# Altium schematic sheet 02_Ext_UART.SchDoc
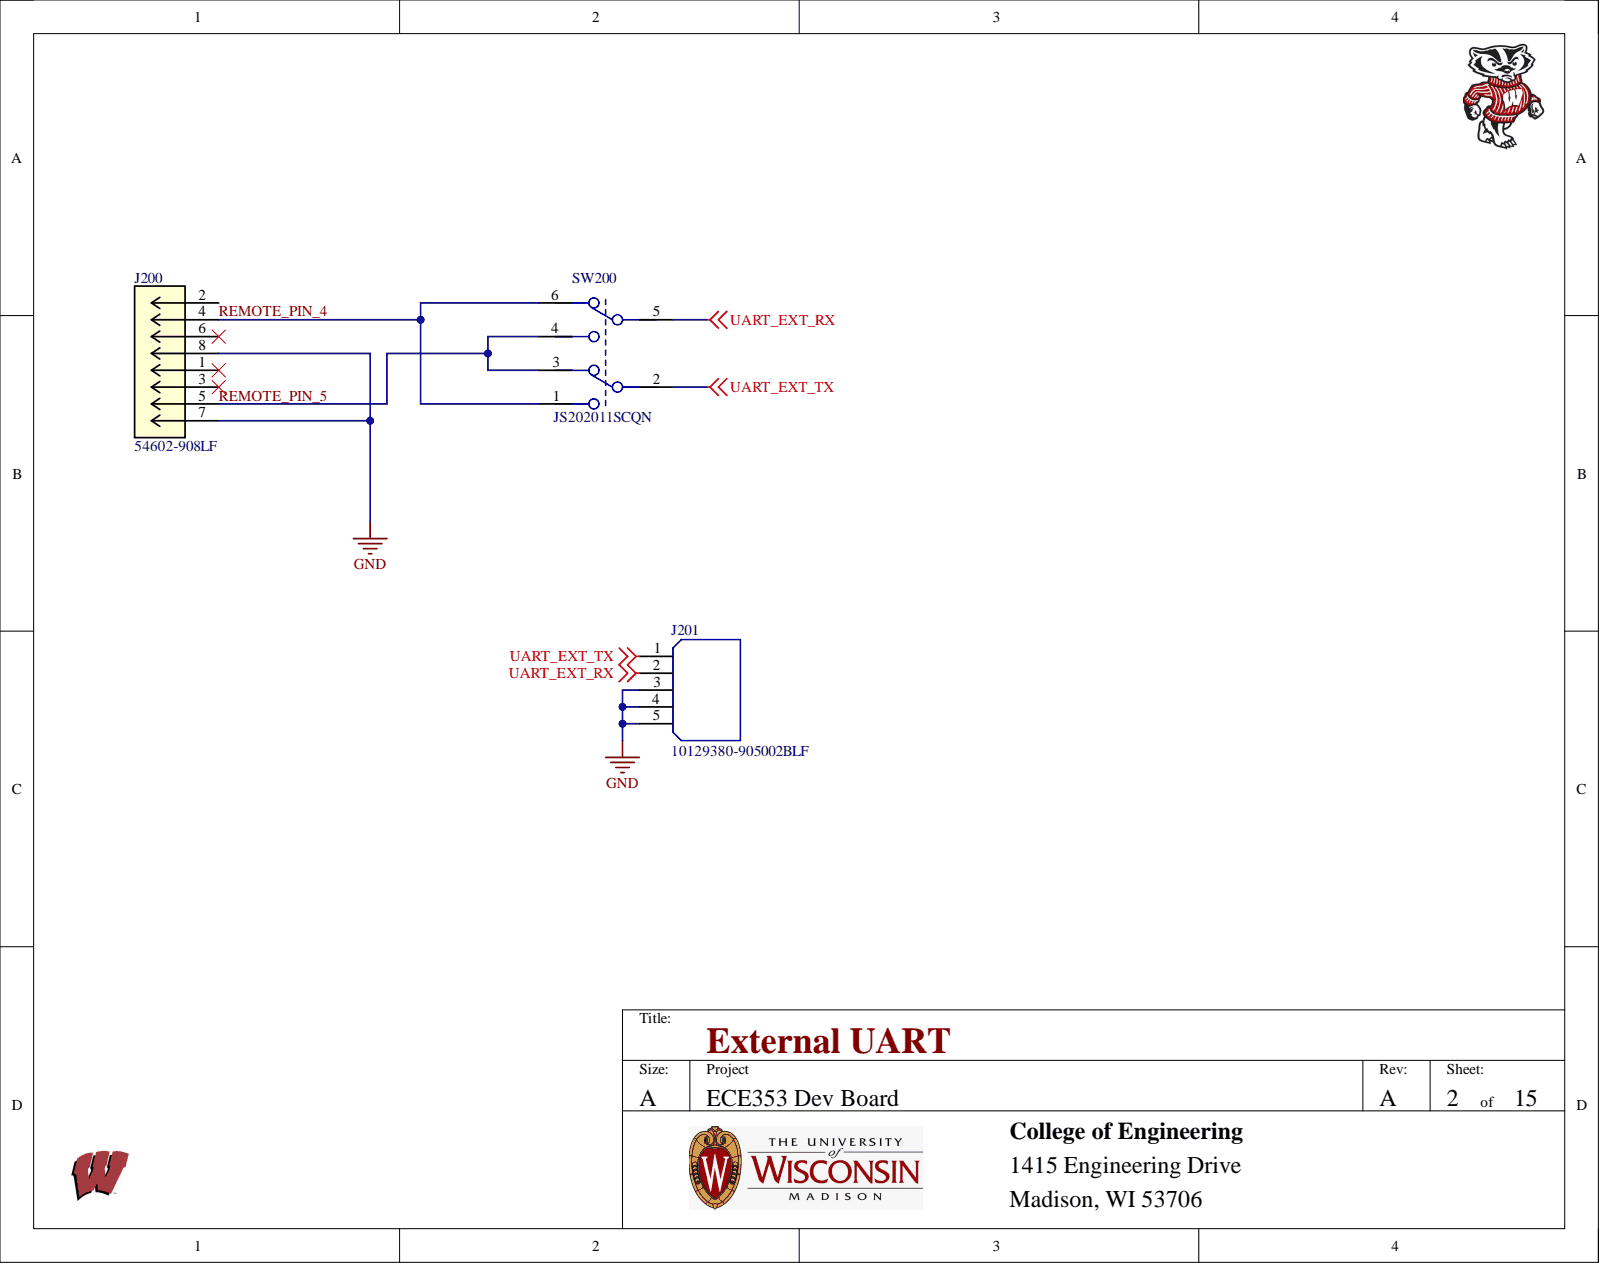
[diagram p0: 02_Ext_UART.SchDoc]

## Parts
J200  54602-908LF  [CONN8_2-908LF_AMP]
J201  10129380-905002BLF  [AMPHENOL_10129380-905002BLF]
SW200  JS202011SCQN  [FP-JS202011SCQN-MFG]

## Nets
GND: J200.7 J200.8 J201.3 J201.4 J201.5
UART_EXT_RX: J201.2 SW200.5
UART_EXT_TX: J201.1 SW200.2
REMOTE_PIN_4: J200.4 SW200.1 SW200.6
REMOTE_PIN_5: J200.5 SW200.3 SW200.4

Not connected: J200 1 2 3 6

## Library data of the parts
J200 = 54602-908LF: Conn Modular Jack F 8 POS 2.54mm Solder RA Thru-Hole 8 Terminal 1 Port Box Cat 3; Manufacturer 1: Amphenol ICC / FCI; Mfr_Name: Amphenol ICC; Category: Modular / Ethernet Connectors; Component Kind: Standard; ComponentLink1Description: https://datasheet.octopart.com/54602-908LF-Areva-datasheet-11761423.pdf?src-supplier=Farnell; ComponentLink1URL: https://datasheet.octopart.com/54602-908LF-Areva-datasheet-11761423.pdf?src-supplier=Farnell; ComponentLink2Description: https://datasheet.octopart.com/54602-908LF-Amphenol-Communications-Solutions-datasheet-94059534.pdf?src-supplier=Element14; ComponentLink2URL: https://datasheet.octopart.com/54602-908LF-Amphenol-Communications-Solutions-datasheet-94059534.pdf?src-supplier=Element14; Library Name: ece353-2022.SchLib; Manufacturer Part Number 1: 54602-908LF; Pricing 1: 1=0.78, 10=0.682, 25=0.6236, 50=0.5868, 100=0.5624, 250=0.51348, 500=0.48904, 1000=0.40346, 2500=0.36678 (USD); RefDes: RefDes; RoHS: No; Stock 1: 193161; Supplier 1: Digi-Key; Supplier Part Number 1: 609-1046-ND; Type: Type
J201 = 10129380-905002BLF: Conn Unshrouded Header HDR 5 POS 2.54mm Solder ST SMD Poly Bag; Manufacturer 1: ICC; MF: AMPHENOL ICC / FCI; Availability: In Stock; Check_prices: https://www.snapeda.com/parts/10129380-905002BLF/Amphenol/view-part/?ref=eda; Component Kind: Standard; Library Name: ece353-2022.SchLib; Manufacturer Part Number 1: 10129380-905002BLF; MP: 10129380-905002BLF; Package: None; Price: None; Purchase-URL: https://pricing.snapeda.com/search/part/10129380-905002BLF/?ref=eda; RoHS: No; SnapEDA_Link: https://www.snapeda.com/parts/10129380-905002BLF/Amphenol/view-part/?ref=snap; Supplier 1: Digi-Key; Supplier Part Number 1: 10129380-905002BLF-ND
SW200 = CMP-2000-05087-3: SWITCH SLIDE DPDT 300MA 6V; Manufacturer 1: ITT C&K; Actuator Color: Black; Actuator Length: 2mm; Actuator Material: Nylon; Actuator Type: Standard; Contact Resistance: 70mR; Electrical Life: 5000Cycles; Switch Function: On-On; Category: Slide Switches; Component Kind: Standard; ComponentLink1Description: https://datasheet.ciiva.com/2889/js-29aug13-2889127.pdf?src-supplier=Digi-Key; ComponentLink1URL: https://datasheet.ciiva.com/2889/js-29aug13-2889127.pdf?src-supplier=Digi-Key; ComponentLink2Description: https://datasheet.ciiva.com/23829/1707718-23829488.pdf?src-supplier=Newark; ComponentLink2URL: https://datasheet.ciiva.com/23829/1707718-23829488.pdf?src-supplier=Newark; ComponentLink3Description: https://datasheet.ciiva.com/22630/1707718-22630697.pdf?src-supplier=Element14; ComponentLink3URL: https://datasheet.ciiva.com/22630/1707718-22630697.pdf?src-supplier=Element14; ComponentLink4Description: https://datasheet.ciiva.com/2889/js-15sept15-2889128.pdf?src-supplier=Digi-Key; ComponentLink4URL: https://datasheet.ciiva.com/2889/js-15sept15-2889128.pdf?src-supplier=Digi-Key; ComponentLink5Description: https://datasheet.ciiva.com/2889/js-15sept15-2889129.pdf?src-supplier=Digi-Key; ComponentLink5URL: https://datasheet.ciiva.com/2889/js-15sept15-2889129.pdf?src-supplier=Digi-Key; ComponentLink6Description: https://datasheet.ciiva.com/8625/js-9dec14-8625470.pdf?src-supplier=Avnet; ComponentLink6URL: https://datasheet.ciiva.com/8625/js-9dec14-8625470.pdf?src-supplier=Avnet; Contact Current Rating: 300mA; Contact Material: Copper Alloy; Contact Plating: Silver; Current Rating: 300mA; Depth: 7.5mm; Flammability Rating: UL94 V-2; Housing Material: Nylon; Lead Free: Lead Free; Length: 9mm; Library Name: Altium Content Vault; Manufacturer Part Number 1: JS202011SCQN; Max Current Rating: 300mA; Max Operating Temperature: 85°C; Max Voltage Rating (DC): 6V; Min Operating Temperature: -20°C; Mount: Surface Mount; Orientation: Vertical; Package Quantity: 1; Packaging: Tape and Reel; Pricing 1: 1=0.56000, 10=0.53700, 25=0.51720, 100=0.44770, 250=0.40788, 500=0.37804 (USD); Radiation Hardening: No; REACH SVHC: No SVHC; Reach SVHC Compliant: Yes; RoHS: Yes; RoHS Compliant: Yes; Series: JS; Stock 1: 2649; Supplier 1: Digi-Key; Supplier Part Number 1: 401-2002-1-ND; Switch Travel: 2mm; Termination: Gull Wing; Throw Configuration: DPDT; Voltage Rating (DC): 6V
